# Altium schematic sheet 2022_AESK_UAV_EXT_CONN.SchDoc(2022_AESK_UAV_EXT_CONN)
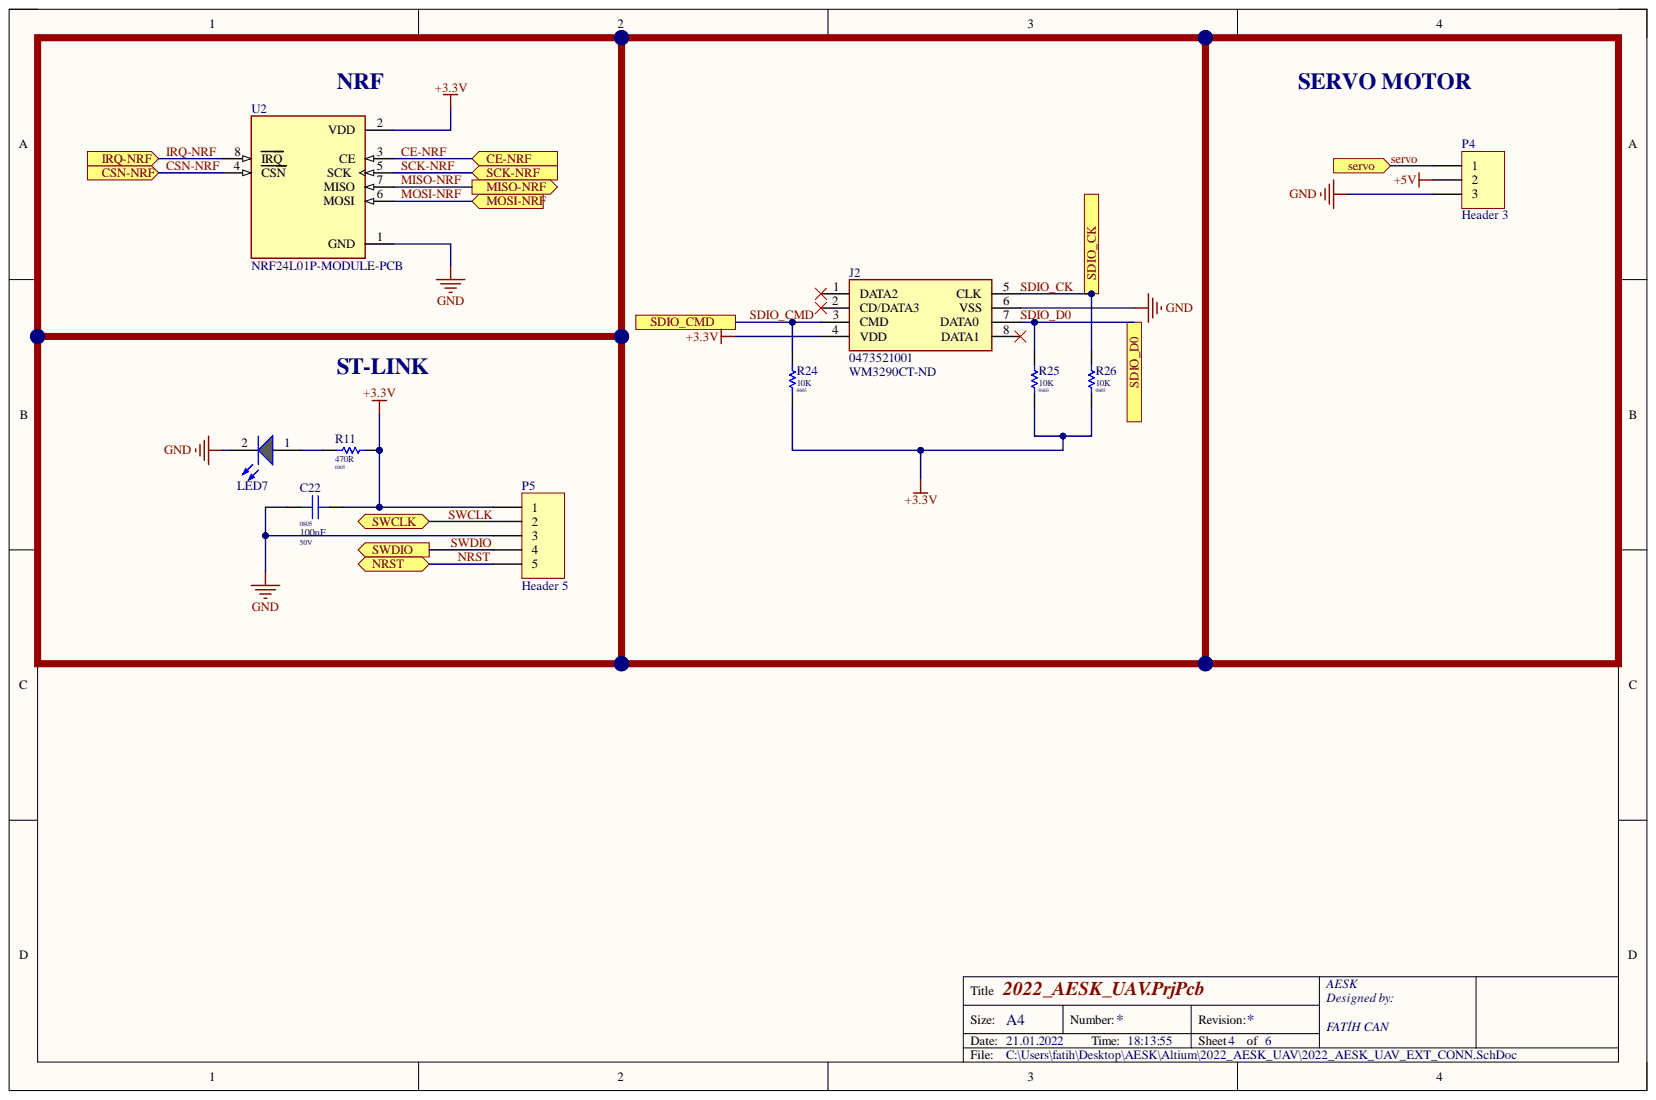
[diagram p0: 2022_AESK_UAV_EXT_CONN.SchDoc]

## Parts
C22  100nF  Package / Case: 0805  Values: 100nF 50V  Voltage: 50V  [CAPC 0805]
J2  0473521001  Supplier Part Number 1: WM3290CT-ND  [47352-1001]
LED7  (no value)  [LED GREEN 0805]
P4  Header 3  [HDR1X3]
P5  Header 5  [HDR1X5]
R11  470R  Package / Case: 0805  [RESC 0805]
R24  10K  Package / Case: 0603  [RESC 0603]
R25  10K  Package / Case: 0603  [RESC 0603]
R26  10K  Package / Case: 0603  [RESC 0603]
U2  NRF24L01P-MODULE-PCB  [nrf]

## Ports
CE-NRF, CSN-NRF, IRQ-NRF, MISO-NRF, MOSI-NRF, NRST, SCK-NRF, SDIO_CK, SDIO_CMD, SDIO_D0, servo, SWCLK, SWDIO

## Nets
+3.3V: C22.2 J2.4(VDD) P5.1 R11.2 R24.1 R25.1 R26.1 U2.2(VDD)
+5V: P4.2
GND: C22.1 J2.6(VSS) LED7.2 P4.3 P5.3 U2.1(GND)
CE-NRF: U2.3(CE) ; port CE-NRF
CSN-NRF: U2.4(CSN) ; port CSN-NRF
IRQ-NRF: U2.8(IRQ) ; port IRQ-NRF
MISO-NRF: U2.7(MISO) ; port MISO-NRF
MOSI-NRF: U2.6(MOSI) ; port MOSI-NRF
NRST: P5.5 ; port NRST
SCK-NRF: U2.5(SCK) ; port SCK-NRF
SDIO_CK: J2.5(CLK) R26.2 ; port SDIO_CK
SDIO_CMD: J2.3(CMD) R24.2 ; port SDIO_CMD
SDIO_D0: J2.7(DATA0) R25.2 ; port SDIO_D0
servo: P4.1 ; port servo
SWCLK: P5.2 ; port SWCLK
SWDIO: P5.4 ; port SWDIO
NetLED7_1: LED7.1 R11.1

Not connected: J2 1(DATA2) 2(CD/DATA3) 8(DATA1)

## Library data of the parts
C22 = CAPC0572: Capacitor Ceramic 100nF 50V 0805; Database Table Name: Ceramic Cap$; Favorites: Favorite; Tolerance: %10; Value Rating: nF; Category: Capacitor Ceramic; Component Kind: Standard; Part Number: CAPC0572; Value: 100nF; Library Name: AESK Database Library.DbLib
J2 = 0473521001: microSD Card Module; Manufacturer_Name: Molex; Component Kind: Standard; Datasheet Link: https://www.molex.com/pdm_docs/sd/473521001_sd.pdf; Manufacturer_Part_Number: 0473521001; Supplier 1: Digi-Key; Library Name: 2022_AESK_UAV.SchLib
LED7 = LED GREEN: LED; Component Kind: Standard; Library Name: Diodes_GESK.SchLib
P4 = Header 3: Header, 3-Pin; Component Kind: Standard; LatestRevisionDate: 17-Jul-2002; LatestRevisionNote: Re-released for DXP Platform.; Library Name: Miscellaneous Connectors.IntLib; Signal Integrity: Connector; Publisher: Altium Limited
P5 = Header 5: Header, 5-Pin; Component Kind: Standard; LatestRevisionDate: 17-Jul-2002; LatestRevisionNote: Re-released for DXP Platform.; Library Name: Miscellaneous Connectors.IntLib; Signal Integrity: Connector; Publisher: Altium Limited
R11 = R0334: 470R 0805; Database Table Name: Resistor$; Power: 1/10W; Tolerance: %5; Category: Resistor; Component Kind: Standard; Part Number: R0334; Value: 470R; Values: 470R; Library Name: AESK Database Library.DbLib
R24-R26 = R0220: 10K 0603; Database Table Name: Resistor$; Power: 1/10W; Tolerance: %5; Category: Resistor; Component Kind: Standard; Part Number: R0220; Value: 10K; Values: 10K; Library Name: AESK Database Library.DbLib
U2 = NRF24L01P-MODULE-PCB: - Transceiver For Use With nRF24L01+; MF: Nordic Semiconductor; Availability: Bad; Component Kind: Standard; Mouser-Purchase-URL: https://snapeda.com/shop?store=Mouser&id=230534; MP: NRF24L01P-MODULE-PCB; Package: None; Price: None; SnapEDA_Link: https://www.snapeda.com/parts/NRF24L01P-MODULE-PCB/Nordic%20Semiconductor%20ASA/view-part/230534/?ref=snap; Library Name: UAV_UcusKontrolKartı_Sematik_Kutuphane.SchLib
